# Altium schematic sheet POWER_SUPPLY.SchDoc
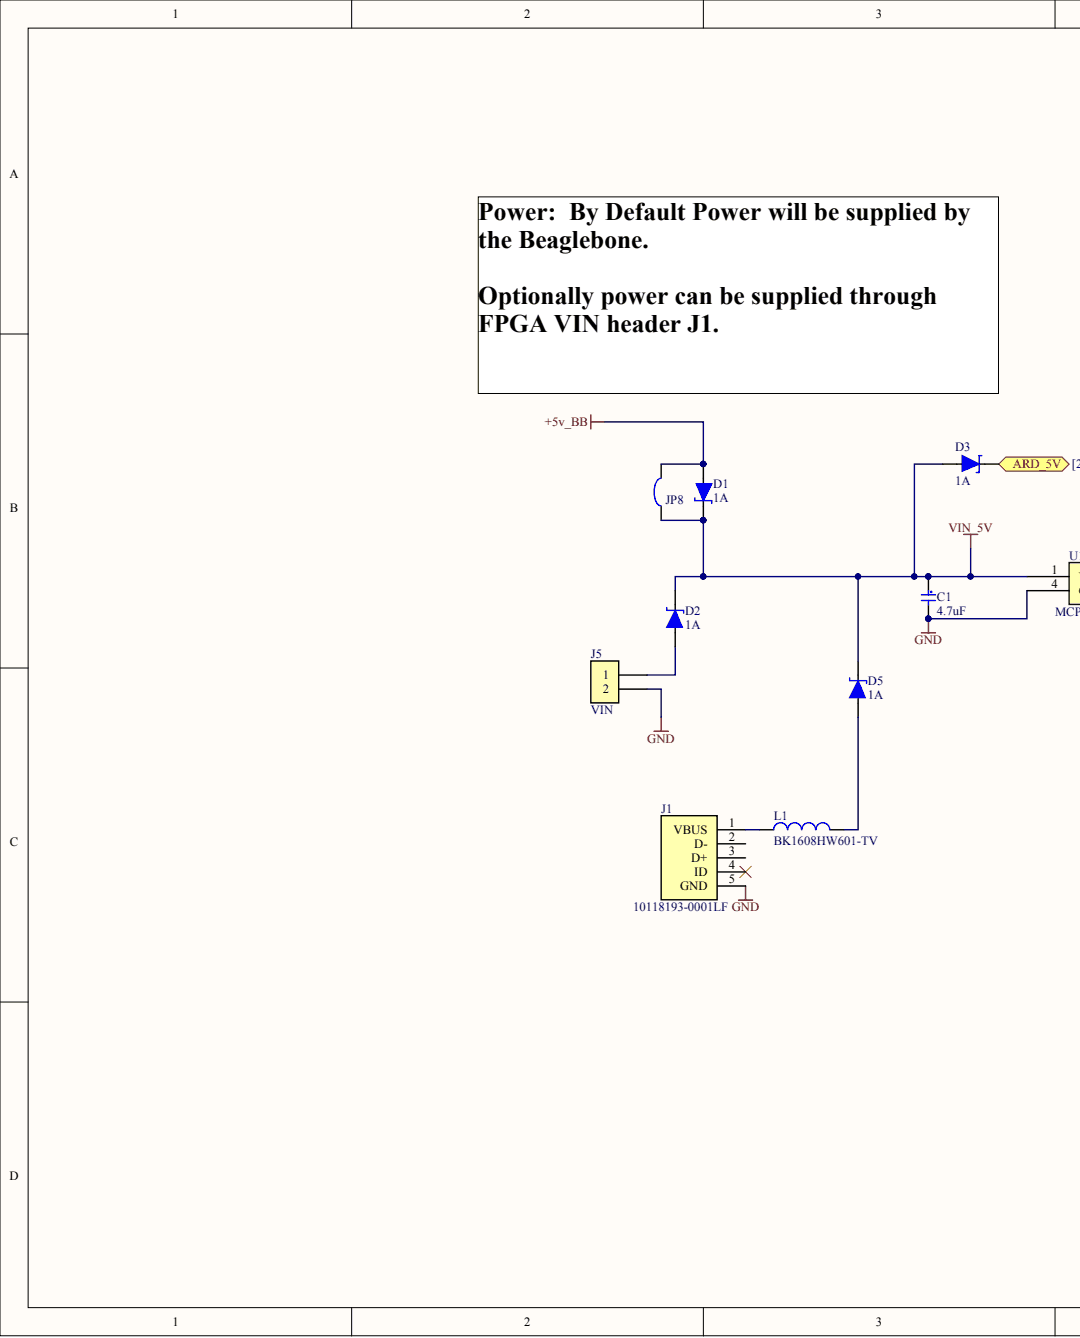
[diagram p0: POWER_SUPPLY.SchDoc, left]
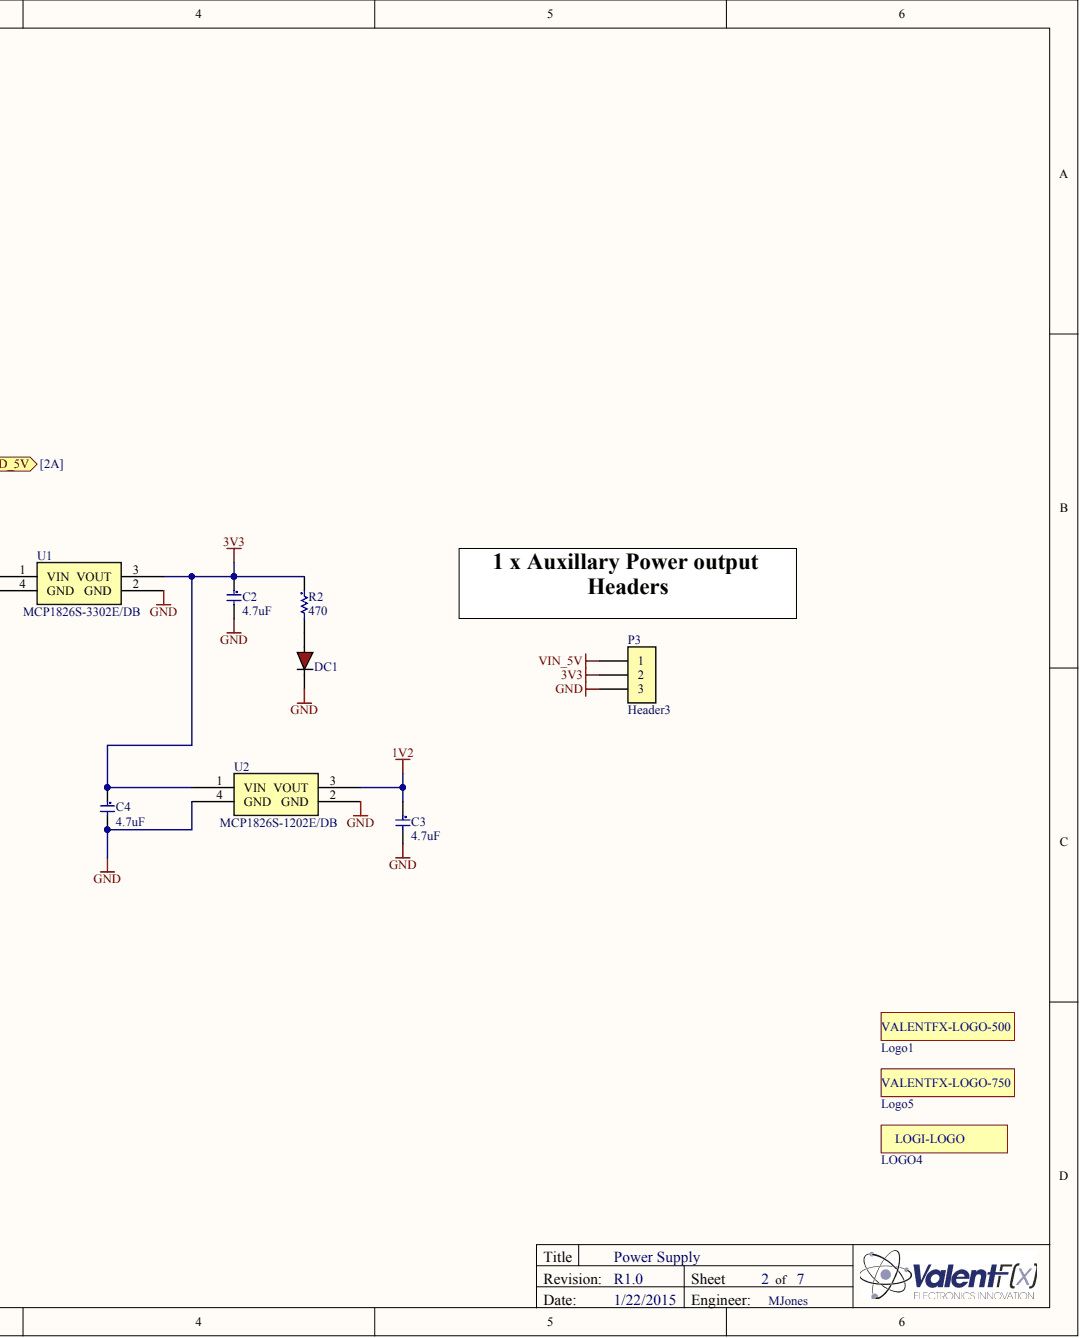
[diagram p1: POWER_SUPPLY.SchDoc, right]

## Parts
C1  4.7uF  [C0603 - Marked]
C2  4.7uF  [C0603 - Marked]
C3  4.7uF  [C0603 - Marked]
C4  4.7uF  [C0603 - Marked]
D1  1A  [SD0805S020S1R0]
D2  1A  [SD0805S020S1R0]
D3  1A  [SD0805S020S1R0]
D5  1A  [SD0805S020S1R0]
DC1  598-8010-107F  [LED_0603 - RED]  (value not drawn)
J1  10118193-0001LF  [USB - 10118193-0001LF_LOW-COST-FAB]
J5  VIN  [HEADER_2_40_100 THRU SIP]  (no BOM)
JP8  Solder Jumper  [SOLDER_JUMPER]  (value not drawn)  (no BOM)
L1  BK1608HW601-TV  [R0603]
Logo1  Valent Logo 500  [VALENTFX_500-SolderMask]  (value not drawn)  (no BOM)
LOGO4  LOGI-LOGO-1  [LOGi-400-SILK]  (value not drawn)  (no BOM)
Logo5  Valent Logo 750  [ValentFX_750]  (value not drawn)  (no BOM)
P3  Header3  [HEADER_3_40_100 THRU SIP]  (no BOM)
R2  470  [R0603]
U1  MCP1826S-3302E/DB  [SOT223]
U2  MCP1826S-1202E/DB  [SOT223]

## Ports
ARD_5V

## Nets
1V2: C3.1 U2.3(VOUT)
3V3: C2.1 C4.1 P3.2 R2.1 U1.3(VOUT) U2.1(VIN)
+5V_BB: D1.A JP8.1
GND: C1.2 C2.2 C3.2 C4.2 DC1.C J1.5(GND) J5.2 P3.3 U1.2(GND) U1.4(GND) U2.2(GND) U2.4(GND)
VIN_5V: C1.1 D1.C D2.C D3.A D5.C JP8.2 P3.1 U1.1(VIN)
ARD_5V: D3.C ; port ARD_5V
NetD2_A: D2.A J5.1
NetD5_A: D5.A L1.2
NetDC1_A: DC1.A R2.2
NetJ1_1: J1.1(VBUS) L1.1

Not connected: J1 2(D-) 3(D+) 4(ID)

## Library data of the parts
C1-C4 = C0603 - GRM188R60J475KE19D: 4.7uF 6.3volts X5R 10% (MLCC) - SMD/SMT 0603; Accept Compatible Replacement Part: Yes; Manufacturer: Murata Electronics North America; Capacitance: 4.7µF; Voltage - Rated: 6.3V; Applications: General Purpose; Category: Capacitors; Component Kind: Standard; ComponentLink1Description: http://search.murata.co.jp/Ceramy/image/img/PDF/ENG/GRM188R60J475KE19.pdf; ComponentLink1URL: http://search.murata.co.jp/Ceramy/image/img/PDF/ENG/GRM188R60J475KE19.pdf; Features: -; Height - Seated (Max): -; Lead Spacing: -; Lead Style: -; Library Name: mCapacitors.SchLib; Manufacturer Part Number: GRM188R60J475KE19D; Mounting Type: Surface Mount, MLCC; Operating Temperature: -55°C ~ 85°C; Package / Case: 0603 (1608 Metric); Packaging: Cut Tape (CT); Pricing 1: 1=0.19, 10=0.132, 50=0.076, 100=0.0627, 250=0.05284, 500=0.04426, 1000=0.03633 (USD); Ratings: -; RoHS: RoHS Compliant; Size / Dimension: 0.063" L x 0.031" W (1.60mm x 0.80mm); Stock 1: 614848; Supplier 1: Digi-Key; Supplier Part Number 1: 490-3297-1-ND; Temperature Coefficient: X5R; Thickness (Max): 0.035" (0.90mm); Tolerance: ±10%; Value: 4.7uF
D1-D3 D5 = SD0805S020S1R0: DIODE SCHOTTKY 20V 1A 0805; Manufacturer: AVX Corporation; Current - Average Rectified (Io): 1A (DC); Current - Reverse Leakage @ Vr: 200µA @ 20V; Speed: Fast Recovery =< 500ns, > 200mA (Io); Voltage - Forward (Vf) (Max) @ If: 450mV @ 1A; Capacitance @ Vr, F: -; Category: Discrete Semiconductor Products; Component Kind: Standard; ComponentLink1Description: http://www.avx.com/docs/Catalogs/schottky.pdf; ComponentLink1URL: http://www.avx.com/docs/Catalogs/schottky.pdf; Diode Type: Schottky; Library Name: mDiode.SchLib; Manufacturer Part Number: SD0805S020S1R0; Mounting Type: Surface Mount; Operating Temperature - Junction: -55°C ~ 125°C; Package / Case: 0805 (2012 Metric); Packaging: Cut Tape (CT); Pricing 1: 1=0.4, 10=0.315, 25=0.266, 100=0.2166, 250=0.1794, 500=0.1482, 1000=0.111 (USD); Reverse Recovery Time (trr): -; RoHS: RoHS Compliant; Stock 1: 25787; Supplier 1: Digi-Key; Supplier Device Package: 0805 (2012 Metric); Supplier Part Number 1: 478-7800-1-ND; Voltage - DC Reverse (Vr) (Max): 20V
DC1 = LED0603 - 598-8010-107F: LED ALINGAP RED CLEAR 0603 SMD; Manufacturer: Dialight; Accept Replacement: YES - Water Clear; Current - Test: 20mA; Lens Style/Size: Rectangle with Flat Top, 1.1mm x 0.8mm; Millicandela Rating: 40mcd; Viewing Angle: 140°; Voltage - Forward (Vf) Typ: 2.2V; Wavelength - Dominant: 635nm; Category: Optoelectronics; Color: Red; Component Kind: Standard; ComponentLink1Description: http://media.digikey.com/pdf/Data%20Sheets/Dialight%20PDFs/598_Series_0603_Pkg.pdf; ComponentLink1URL: http://media.digikey.com/pdf/Data%20Sheets/Dialight%20PDFs/598_Series_0603_Pkg.pdf; Height: 0.70mm; Lens Type: Clear; Library Name: mDiode.SchLib; Luminous Flux @ Current - Test: -; Manufacturer Part Number: 598-8010-107F; Mounting Type: Surface Mount; Package / Case: 0603 (1608 Metric); Packaging: Digi-Reel®; Pricing 1: 1=0.45, 10=0.33, 25=0.264, 100=0.1749, 250=0.1452, 500=0.1056, 1000=0.0825 (USD); RoHS: RoHS Compliant; Size / Dimension: 1.60mm L x 0.80mm W; Stock 1: 37578; Supplier 1: Digi-Key; Supplier Part Number 1: 350-2029-6-ND; Wavelength - Peak: -
J1 = USB - 10118193-0001LF: CONN USB MICRO B RECPT SMT R/A; Manufacturer: FCI; Connector Type: USB - micro B; Gender: Receptacle; Number of Contacts: 5; Specifications: USB 2.0; Category: Connectors, Interconnects; Component Kind: Standard; ComponentLink1Description: http://portal.fciconnect.com/Comergent//fci/drawing/10118193.pdf; ComponentLink1URL: http://portal.fciconnect.com/Comergent//fci/drawing/10118193.pdf; Features: Shielded, Solder Retention; Ingress Protection: -; Library Name: mConnector.SchLib; Manufacturer Part Number: 10118193-0001LF; Mounting Type: Surface Mount, Right Angle, Horizontal; Packaging: Cut Tape (CT); Pricing 1: 1=0.46, 10=0.433, 25=0.3712, 50=0.3156, 100=0.3032, 250=0.27224, 500=0.25988 (USD); RoHS: RoHS Compliant; Stock 1: 75921; Supplier 1: Digi-Key; Supplier Part Number 1: 609-4616-1-ND
J5 = Header2: Header 2 pin; Library Name: mConnector.SchLib
JP8 = Solder Jumper: Solder/Configuration Jumper; Library Name: mConnector.SchLib; Manufacturer: n/a; Manufacturer Part Number: n/a; ROHS Certificate: n/a; Source: n/a; Source Part Number: n/a
L1 = FER - BK1608HW601-TV: FERRITE BEAD 600 OHM 0603; Manufacturer: Taiyo Yuden; Category: Filters; Component Kind: Standard; ComponentLink1Description: http://www.yuden.co.jp/productdata/catalog/en/mlci07_e.pdf; ComponentLink1URL: http://www.yuden.co.jp/productdata/catalog/en/mlci07_e.pdf; Library Name: mInductor.SchLib; Manufacturer Part Number: BK1608HW601-TV; Pricing 1: 1=0.13, 10=0.108, 50=0.0716, 100=0.0618, 250=0.04876, 500=0.04118, 1000=0.03467 (USD); RoHS: RoHS Compliant; Stock 1: 8000; Supplier 1: Digi-Key; Supplier Part Number 1: 587-3909-6-ND
P3 = Header3: Header  pin; Library Name: mConnector.SchLib
R2 = R0603 - CRCW0603470RJNEA: RES 470 OHM 1/10W 5% 0603 SMD; Manufacturer: Vishay Dale; Power (Watts): 0.1W, 1/10W; Temperature Coefficient: ±200ppm/°C; Category: Resistors; Component Kind: Standard; ComponentLink1Description: http://www.vishay.com/docs/20035/dcrcwe3.pdf; ComponentLink1URL: http://www.vishay.com/docs/20035/dcrcwe3.pdf; Composition: Thick Film; Features: Automotive AEC-Q200 Qualified; Height: 0.020" (0.50mm); Library Name: mResistors.SchLib; Manufacturer Part Number: CRCW0603470RJNEA; Number of Terminations: 2; Operating Temperature: -55°C ~ 155°C; Package / Case: 0603 (1608 Metric); Packaging: Digi-Reel®; Pricing 1: 10=0.08, 50=0.0432, 200=0.0248, 1000=0.01694 (USD); Resistance (Ohms): 470; RoHS: RoHS Compliant; Size / Dimension: 0.061" L x 0.033" W (1.55mm x 0.85mm); Stock 1: 83706; Supplier 1: Digi-Key; Supplier Device Package: 0603 (1608 Metric); Supplier Part Number 1: 541-470GDKR-ND; Tolerance: ±5%
U1 = REG - MCP1826S-3302E/DB: LDO REG 1A 3.3V SOT223-3; Manufacturer: Microchip Technology; Current - Output: 1A (Min); Number of Regulators: 1; Regulator Topology: Positive Fixed; Voltage - Dropout (Typical): 0.25V @ 1A; Voltage - Input: Up to 6V; Category: Integrated Circuits (ICs); Component Kind: Standard; ComponentLink1Description: http://ww1.microchip.com/downloads/en/DeviceDoc/22057a.pdf; ComponentLink1URL: http://ww1.microchip.com/downloads/en/DeviceDoc/22057a.pdf; Current - Limit (Min): -; Library Name: mIC.SchLib; Manufacturer Part Number: MCP1826S-3302E/DB; Mounting Type: Surface Mount; Operating Temperature: -40°C ~ 125°C; Package / Case: TO-261-4, TO-261AA; Packaging: Tube; Pricing 1: 1=0.74, 10=0.68, 25=0.57, 100=0.52 (USD); RoHS: RoHS Compliant; Stock 1: 2041; Supplier 1: Digi-Key; Supplier Device Package: SOT-223-3; Supplier Part Number 1: MCP1826S-3302E/DB-ND; Value: MCP1826S-3302E/DB; Voltage - Output: 3.3V
U2 = MCP1826S-1202E/DB: IC LDO REG 1A 1.2V SOT223-3; Manufacturer: Microchip Technology; Current - Output: 1A (Min); Number of Regulators: 1; Regulator Topology: Positive Fixed; Voltage - Dropout (Typical): 0.25V @ 1A; Voltage - Input: Up to 6V; Category: Integrated Circuits (ICs); Component Kind: Standard; ComponentLink1Description: http://ww1.microchip.com/downloads/en/DeviceDoc/22057a.pdf; ComponentLink1URL: http://ww1.microchip.com/downloads/en/DeviceDoc/22057a.pdf; Current - Limit (Min): -; Library Name: eIC.SchLib; Manufacturer Part Number: MCP1826S-1202E/DB; Mounting Type: Surface Mount; Operating Temperature: -40°C ~ 125°C; Package / Case: TO-261-4, TO-261AA; Packaging: Tube; Pricing 1: 1=0.74, 10=0.68, 25=0.57, 100=0.52 (USD); RoHS: RoHS Compliant; Stock 1: 2041; Supplier 1: Digi-Key; Supplier Device Package: SOT-223-3; Supplier Part Number 1: MCP1826S-1202E/DB-ND; Value: MCP1826S-1202E/DB; Voltage - Output: 1.2V
Logo1 = Valent Logo 500: Library Name: mLogos.SchLib
LOGO4 = LOGI-LOGO-1: Library Name: mLogos.SchLib
Logo5 = Valent Logo 750: Library Name: mLogos.SchLib
